# Altium schematic sheet 11-Power.SchDoc(11-Power)
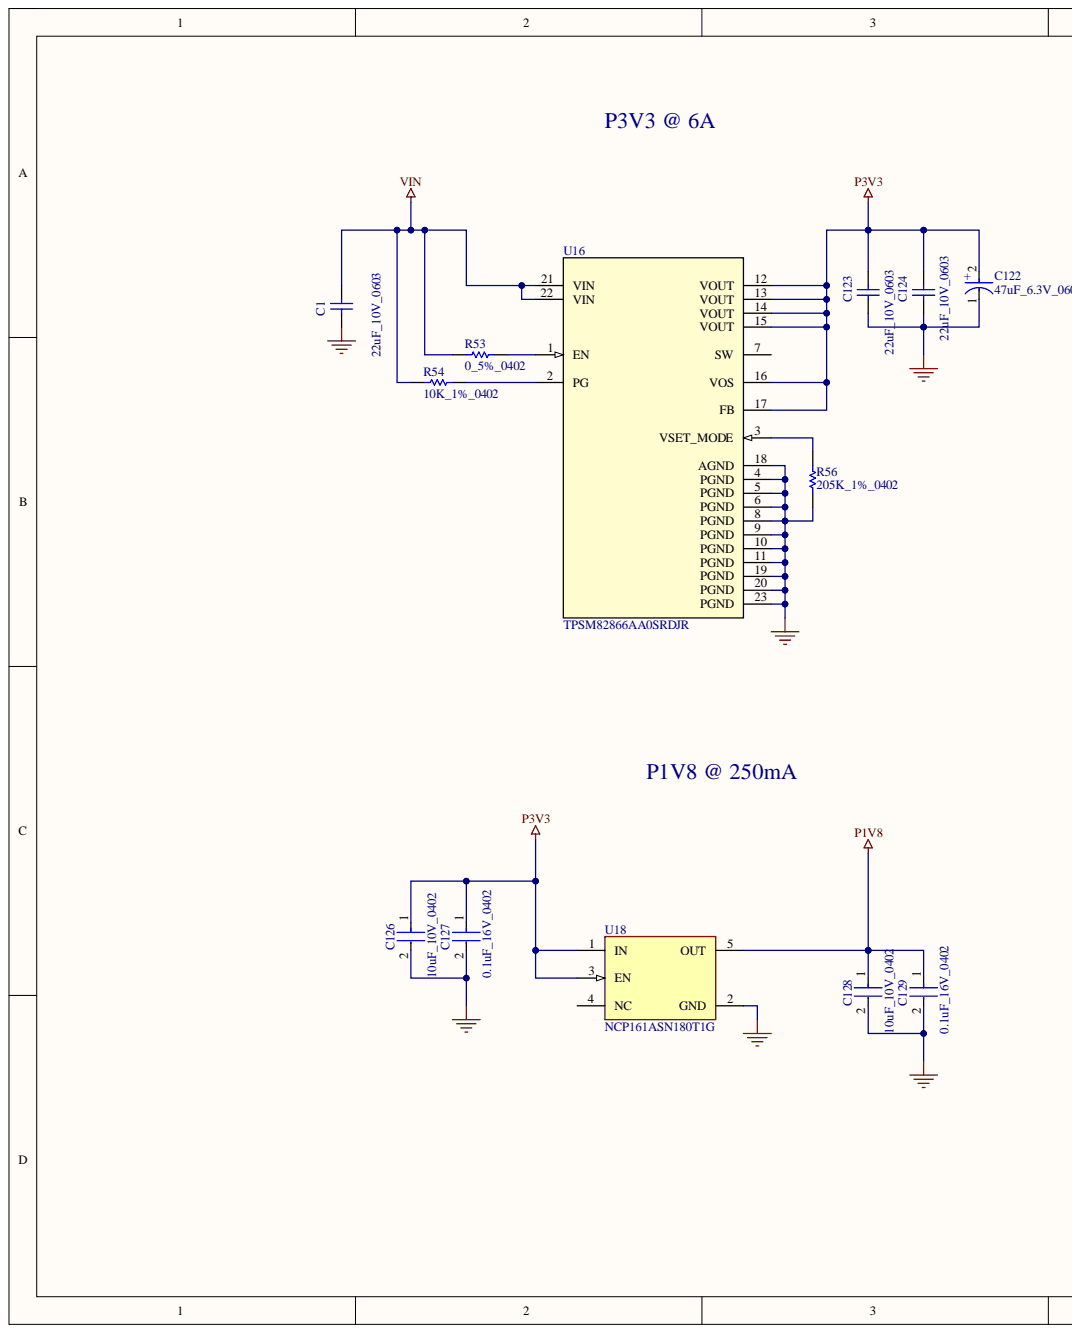
[diagram p0: 11-Power.SchDoc, left]
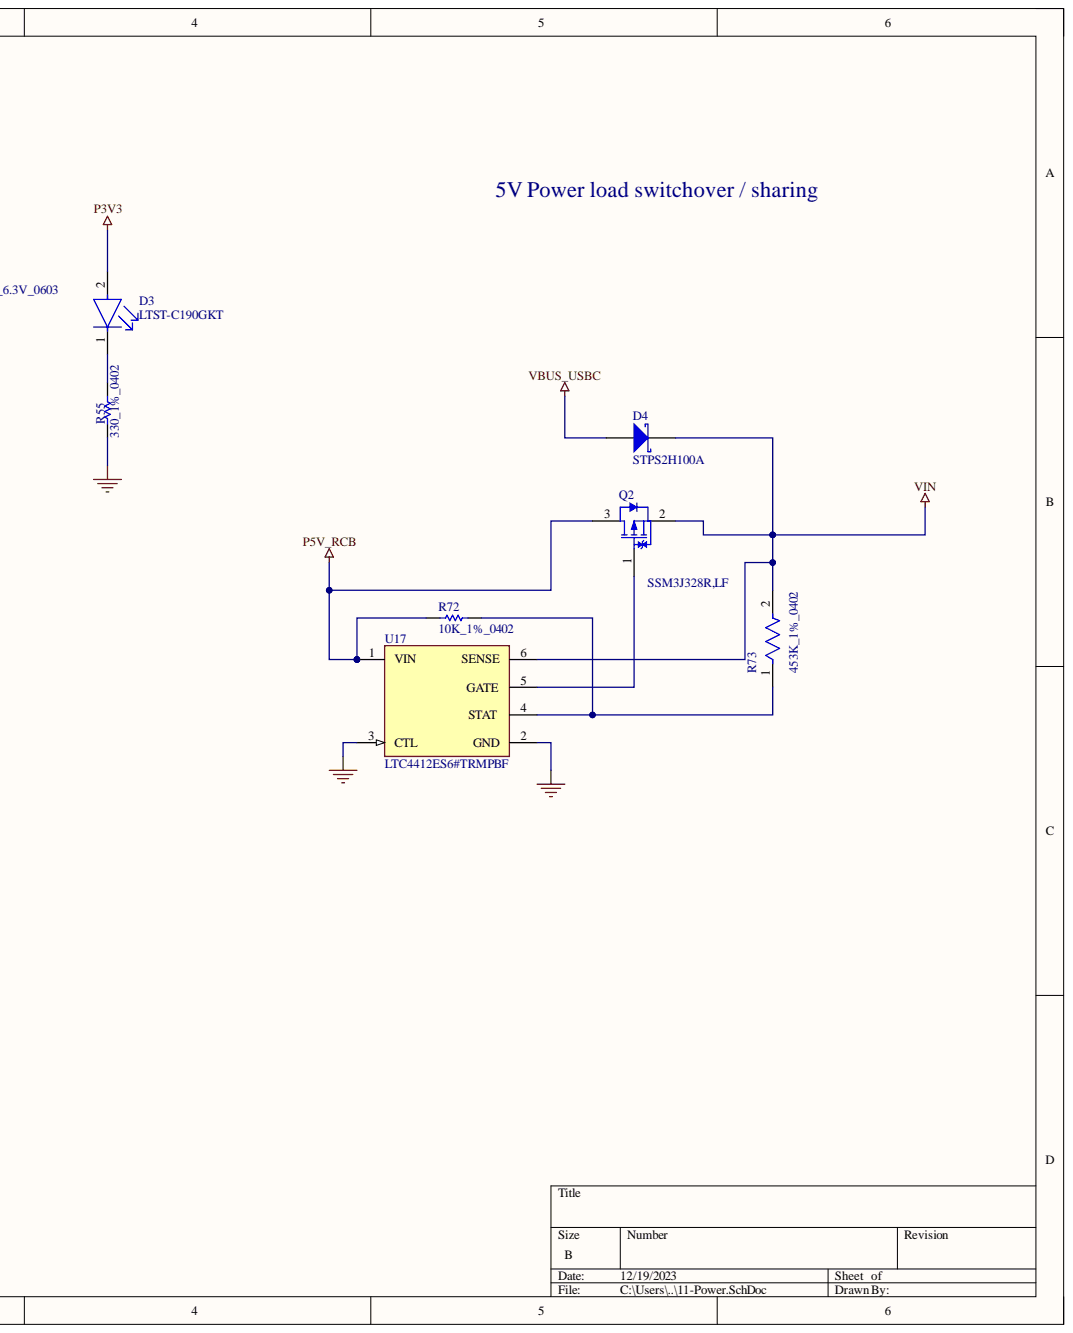
[diagram p1: 11-Power.SchDoc, right]

## Parts
C1  22uF_10V_0603  [CAPC1608X100X20ML10]
C122  47uF_6.3V_0603  [FP-F98M-MFG]
C123  22uF_10V_0603  [CAPC1608X100X20ML10]
C124  22uF_10V_0603  [CAPC1608X100X20ML10]
C126  10uF_10V_0402  [FP-CL05A106MP5NUNC-MFG]
C127  0.1uF_16V_0402  [FP-C0402-BB-MFG]
C128  10uF_10V_0402  [FP-CL05A106MP5NUNC-MFG]
C129  0.1uF_16V_0402  [FP-C0402-BB-MFG]
D3  LTST-C190GKT  [FP-LTST-C190GKT-MFG]
D4  STPS2H100A  [STM-SMA-2_V]
Q2  SSM3J328R,LF  [TOSH-SOT-23F_V]
R53  0_5%_0402  [RESC1005X40X25NL05T10]
R54  10K_1%_0402  [RESC1005X40X25NL10T10]
R55  330_1%_0402  [RESC1005X40X25NL10T10]
R56  205K_1%_0402  [RESC1005X40X25ML05T10]
R72  10K_1%_0402  [RESC1005X40X25NL10T10]
R73  453K_1%_0402  [FP-RC1005-IPC_B]
U16  TPSM82866AA0SRDJR  [RDJ0023A-MFG]
U17  LTC4412ES6#TRMPBF  [FP-S6-6-05-08-1636-IPC_C]
U18  NCP161ASN180T1G  [FP-527AH-MFG]

## Nets
GND: C1.1 C122.1 C123.1 C124.1 C126.2 C127.2 C128.2 C129.2 R55.1 R56.1 U16.4(PGND) U16.5(PGND) U16.6(PGND) U16.8(PGND) U16.9(PGND) U16.10(PGND) U16.11(PGND) U16.18(AGND) U16.19(PGND) U16.20(PGND) U16.23(PGND) U17.2(GND) U17.3(CTL) U18.2(GND)
P1V8: C128.1 C129.1 U18.5(OUT)
P3V3: C122.2 C123.2 C124.2 C126.1 C127.1 D3.2 U16.12(VOUT) U16.13(VOUT) U16.14(VOUT) U16.15(VOUT) U16.16(VOS) U16.17(FB) U18.1(IN) U18.3(EN)
P5V_RCB: Q2.3 R72.1 U17.1(VIN)
VBUS_USBC: D4.1
VIN: C1.2 D4.2 Q2.2 R53.2 R54.2 R73.2 U16.21(VIN) U16.22(VIN) U17.6(SENSE)
NetD3_1: D3.1 R55.2
NetQ2_1: Q2.1 U17.5(GATE)
NetR53_1: R53.1 U16.1(EN)
NetR54_1: R54.1 U16.2(PG)
NetR56_2: R56.2 U16.3(VSET_MODE)
NetR72_2: R72.2 R73.1 U17.4(STAT)

Not connected: U16 7(SW); U18 4(NC)

## Library data of the parts
C1 C123 C124 = CMP-2000-05441-1: Chip Capacitor, 22 uF, +/- 20%, 10 V, -55 to 85 degC, 0603 (1608 Metric), RoHS, Tape and Reel; Manufacturer: TDK; PCN Part Number: MLCCPartNumberChange30/Nov/2012; Capacitance: 22µF; Design Resources: 20WNon-IsolatedBuckLEDDriverUsingPLLNK460KG; Kits: 445-9014-KIT-ND-KITMLCC100PF-22UF060310-50V; Online Catalog: CSeries,GeneralPurpose; Other Names: 445-9077-1; Product Training Modules: CSeriesGeneralApplicationsSEAT,CCV,andTVCLDesignToolsGuidetoReplacinganElectrolyticCapacitorwithanMLCC; Temperature Coefficient: X5R; Voltage - Rated: 10V; Applications: GeneralPurpose; Category: Capacitors; Component Kind: Standard; Datasheet URL: http://download.siliconexpert.com/pdfs/2015/4/21/15/54/45/779/tdk_/manual/37024850441143360mlcc_commercial_general_en.pdf; Datasheet Version: Mar-15; Family: CeramicCapacitors; Features: -; Height - Seated (Max): -; Lead Spacing: -; Lead Style: -; Library Name: Altium Content Vault; Manufacturer URL: http://www.tdk.com/; Mounting Type: SurfaceMount,MLCC; Operating Temperature: -55degC~85degC; Package / Case: 0603(1608Metric); Package Description: 2-Pin Surface Mount Device, Body 1.6 x 0.8 mm; Package Reference: 603; Packaging: CutTape(CT); Ratings: -; RoHS Information: RoHSCertificate-MLCC; Series: C; Size / Dimension: 0.063"Lx0.031"W(1.60mmx0.80mm); Standard Package: 1; Thickness (Max): 0.035"(0.90mm); Tolerance: ±20%
C122 = CMP-04424-000038-1: CAP TANT 47UF 20% 6.3V 0603; Manufacturer: AVX Corporation; Manufacturer Part Number: F980J476MMA; Dissipation Factor: 45%; Esr: 10Ω; Leakage Current: 29.6uA; Life: 1000Hours; Material: Tantalum; Ripple Current: 50mA; Temperature Coefficient: 30%; Automotive: No; Capacitance: 47uF; Category: Cap; Component Kind: Standard; ComponentLink2Description: Datasheet; ComponentLink2URL: http://datasheets.avx.com/F98.pdf; Device Class L1: Passive Components; Device Class L2: Capacitors; Device Class L3: Tantalum Capacitors; Digikey Description: CAP TANT 47UF 20% 6.3V 0603; Footprint Url: http://www.avx.com/download/software/literature/AVX-Footprint-Library.pdf; Height: 0.9mm; Lead Free: Yes; Library Name: Altium Content Vault; Mouser Description: Tantalum Capacitors - Solid SMD 47uF 6.3V 20% 0603,1.6x0.85x0.65mm; Octopart: https://octopart.com/f980j476mma-avx-28870486; Package: F98M; RoHS: TRUE; Temperature: -55°C to +125°C; Temperature Range High: +125°C; Temperature Range Low: -55°C; Tolerance: 20%; Value: 47uF; Voltage Rating: 6.3V
C126 C128 = CMP-13271-000002-1: CAP CER 10UF 10V X5R 0402; Manufacturer: Samsung; Manufacturer Part Number: CL05A106MP5NUNC; Material: Ceramic; Temperature Coefficient: 15%; Tolerance: 20%; Automotive: No; Capacitance: 10uF; Category: Cap; Component Kind: Standard; ComponentLink2Description: Datasheet; ComponentLink2URL: http://www.samsungsem.com/kr/support/product-search/mlcc/__icsFiles/afieldfile/2019/05/10/19-CL05A106MP5NUNC.pdf; Device Class L1: Passive Components; Device Class L2: Capacitors; Device Class L3: Ceramic Capacitors; Digikey Description: CAP CER 10UF 10V X5R 0402; Height: 0.7mm; Ipc Land Pattern Name: CAPC10050X50; Lead Free: Yes; Library Name: Altium Content Vault; Octopart: https://octopart.com/cl05a106mp5nunc-samsung-22457395; Package: CL05A106MP5NUNC; RoHS: TRUE; Temperature: -55°C to +85°C; Temperature Characteristic: X5R; Temperature Range High: +85°C; Temperature Range Low: -55°C; Value: 10uF; Voltage Rating: 10V
C127 C129 = CMP-1034-02068-3: CAP CER 0.1UF 16V 5% X7R 0402; Manufacturer: Kemet; Manufacturer Part Number: C0402C104J4RACTU; Capacitance: 0.10 μF; Material: Ceramic; Temperature Coefficient: 15%; Tolerance: 0.05; Value: 0.10 μF; Automotive: No; Category: Cap; Component Kind: Standard; ComponentLink2Description: Datasheet; ComponentLink2URL: https://content.kemet.com/datasheets/KEM_C1002_X7R_SMD.pdf; Device Class L1: Passive Components; Device Class L2: Capacitors; Device Class L3: Ceramic Capacitors; Digikey Description: CAP CER 0.1UF 16V 5% X7R 0402; Height: 0.55mm; Ipc Land Pattern Name: CAPC10050X50; Lead Free: Yes; Library Name: Altium Content Vault; Mouser Description: CAP CER 0.1UF 16V X7R 0402; Octopart: https://octopart.com/c0402c104j4ractu-kemet-12573893; Package: C0402-BB; RoHS: TRUE; Temperature: -55°C to +125°C; Temperature Characteristic: X7R; Temperature Range High: +125°C; Temperature Range Low: -55°C; Voltage Rating: 16 V
D3 = CMP-22017-000005-2: LED GREEN CLEAR CHIP SMD; Dominant Wavelength: 569nm; Forward Current: 30mA; Forward Voltage: 2.1V; Lens Color: Colorless; Luminous Intensity: 6mcd; Number of Elements: 1; Power Dissipation: 100mW; Reverse Voltage: 5V; Test Current: 10mA; Viewing Angle: 130°; Voltage: 2V; Wavelength: 565nm; Case Code (Imperial): 0603; Case Code (Metric): 1608; Case/Package: 0603; Color: Green; Component Kind: Standard; Depth: 0.8mm; Height: 0.8mm; Illumination Color: Green; Lead Free: Lead Free; Length: 1.6mm; Lens Style: Clear; Lens Transparency: Clear; Library Name: Altium Content Vault; Max Operating Temperature: 85°C; Min Operating Temperature: -55°C; Mount: Surface Mount; Number of LEDs: 1; Number of Pins: 2; Package Quantity: 1; Packaging: Tape and Reel; Power Rating: 100mW; Radiation Hardening: No; Width: 0.8mm
D4 = CMP-2000-07175-1: Power Schottky Rectifier, 2 A, 100 V, 2-Pin SMD (SMA), RoHS, Tape and Reel; Manufacturer: STMicroelectronics; Current - Average Rectified (Io): 2A; Current - Reverse Leakage @ Vr: 1µA@100V; Online Catalog: SchottkyDiode; Other Names: 497-3758-6; Other Related Documents: STPS2H100ViewAllSpecifications; Speed: FastRecovery=200mA(Io); Voltage - DC Reverse (Vr) (Max): 100V; Voltage - Forward (Vf) (Max) @ If: 790mV@2A; Capacitance @ Vr, F: -; Category: DiscreteSemiconductorProducts; Component Kind: Standard; Datasheet URL: http://www.st.com/content/ccc/resource/technical/document/datasheet/0a/8b/df/ef/15/72/4f/38/CD00001548.pdf/files/CD00001548.pdf/jcr:content/translations/en.CD00001548.pdf; Datasheet Version: 06/2013; Diode Type: Schottky; Family: Diodes,Rectifiers-Single; Library Name: Altium Content Vault; Manufacturer URL: http://www.st.com/; Mounting Technology: SurfaceMount; Mounting Type: SurfaceMount; Operating Temperature - Junction: 175°C(Max); Package / Case: DO-214AC,SMA; Package Description: 2-Pin Surface Mount Device, Body 4.275 x 2.575 mm; Package Reference: SMA; Package URL: http://www.st.com/st-web-ui/static/active/en/resource/technical/document/datasheet/CD00283518.pdf; Packaging: Digi-Reel®; Reverse Recovery Time (trr): -; Series: -; Standard Package: 1; Supplier Device Package: SMA(DO-214AC)
Q2 = CMP-2100-03686-1: TOSHIBA Field-Effect Transistor Silicon P-Channel MOS Type (U-MOS VI), 20 V, -55 to 125 degC, 3-Pin SOTFL, RoHS, Tape and Reel; Continuous Drain Current (ID): 6A; Drain to Source Breakdown Voltage: -20V; Drain to Source Resistance: 47.4mOhm; Drain to Source Voltage (Vdss): 20V; Element Configuration: Single; Gate to Source Voltage (Vgs): 8V; Input Capacitance: 840pF; Max Power Dissipation: 1W; Number of Channels: 1; Power Dissipation: 2W; Rds On Max: 29.8mOhm; Turn-Off Delay Time: 107ns; Turn-On Delay Time: 32ns; Case/Package: SOT-23-3; Component Kind: Standard; Datasheet URL: https://toshiba.semicon-storage.com/info/docget.jsp?did=2429&prodName=SSM3J328R; Datasheet Version: 41699.0; Library Name: Altium Content Vault; Manufacturer: Toshiba; Manufacturer URL: http://www.toshiba.com/; Max Operating Temperature: 150degC; Min Operating Temperature: -55degC; Mount: SurfaceMount; Number of Elements: 1; Number of Pins: 3; Package Description: 3-Pin Small Outline Transistor, Flat Lead, Body 2.9 x 1.8 mm, Pitch 0.95 mm; Package Quantity: 3000; Package Reference: SOT-23F; Package URL: https://toshiba.semicon-storage.com/ap-en/design-support/package/detail.SOT-23F.html; Packaging: TapeandReel; Radiation Hardening: No; RoHSCompliant: true
R53 = CMP-2002-06457-1: Manufacturer: Panasonic; Part Number: ERJ-U020R00X; Component Kind: Standard; Library Name: Altium Content Vault
R54 R72 = CMP-2002-04694-1: Manufacturer: Bourns; Part Number: CR0402-FX-1002GLF; Component Kind: Standard; Library Name: Altium Content Vault
R55 = CMP-2002-05853-1: Manufacturer: Panasonic; Part Number: ERA-2AED331X; Component Kind: Standard; Library Name: Altium Content Vault
R56 = CMP-1011-00769-2: Manufacturer: Yageo / Phycomp; Part Number: RC0402FR-07205KL; Component Kind: Standard; Library Name: Altium Content Vault
R73 = CMP-13261-000628-1: Res Thick Film 0402 453kΩ 1% 0.063W ±100ppm/°C Molded SMD T/R; Max Power Dissipation: 63mW; Resistance: 453kR; Voltage Rating (DC): 50V; Case Code (Imperial): 0402; Case Code (Metric): 1005; Case/Package: 0402; Component Kind: Standard; Composition: Thick Film; Contact Plating: Tin; Features: Moisture Resistant; Height: 0.016inch; Lead Free: Lead Free; Length: 0.039inch; Library Name: Altium Content Vault; Max Operating Temperature: 155°C; Min Operating Temperature: -55°C; Number of Terminations: 2; Packaging: Tape and Reel; Power Rating: 63mW; Radiation Hardening: No; Temperature Coefficient: 100ppm/°C; Tolerance: 1%; Width: 0.02inch
U16 = TPSM82866AA0SRDJR: No Description Available; Mfr_Name: Texas Instruments; Component Kind: Standard; Library Name: TPSM82866AA0SRDJR.SchLib; Manufacturer_Part_Number: TPSM82866AA0SRDJR; RefDes: RefDes; Type: DEV; Copyright: Copyright (C) 2023 Ultra Librarian. All rights reserved.
U17 = CMP-0479-00425-2: IC OR CTRLR SRC SELECT TSOT23-6; Max Supply Voltage: 28V; Min Supply Voltage: 2.5V; Number of Channels: 1; Turn-On Delay Time: 110us; Case/Package: TSOT; Component Kind: Standard; Height: 0.9mm; Lead Free: Lead Free; Length: 2.9mm; Library Name: Altium Content Vault; Max Operating Temperature: 85°C; Min Operating Temperature: -40°C; Number of Outputs: 1; Number of Pins: 6; Package Quantity: 1; Packaging: Tape and Reel; Radiation Hardening: No; REACH SVHC: No SVHC; RoHS Compliant: Yes; Series: PowerPath™; Width: 1.75mm
U18 = CMP-00278-92192183-1: NCP161ASN180T1G LDO Regulator, 450 mA, Ultra-High PSRR, Ultra-Low Noise 1.8V, SOT23-5L, Active Discharge; Accuracy: 2%; Dropout Voltage: 365mV; Max Junction Temperature (Tj): 150°C; Max Supply Voltage: 5.5V; Min Supply Voltage: 2.8V; Nominal Supply Current: 18µA; Number of Channels: 1; Output Current: 450mA; Output Type: Fixed; Case/Package: SOT-23-5; Component Kind: Standard; Height: 1.45mm; Library Name: Altium Content Vault; Lifecycle Status: Production; Manufacturer Lifecycle Status: ACTIVE; Max Operating Temperature: 150°C; NexarPartId: 92192183; Number of Outputs: 1; Output Voltage: 1.8V; RoHS: Compliant; Schedule B: 8542390000
